# Altium schematic sheet Stepper_Driver_Arduino_uC.SchDoc
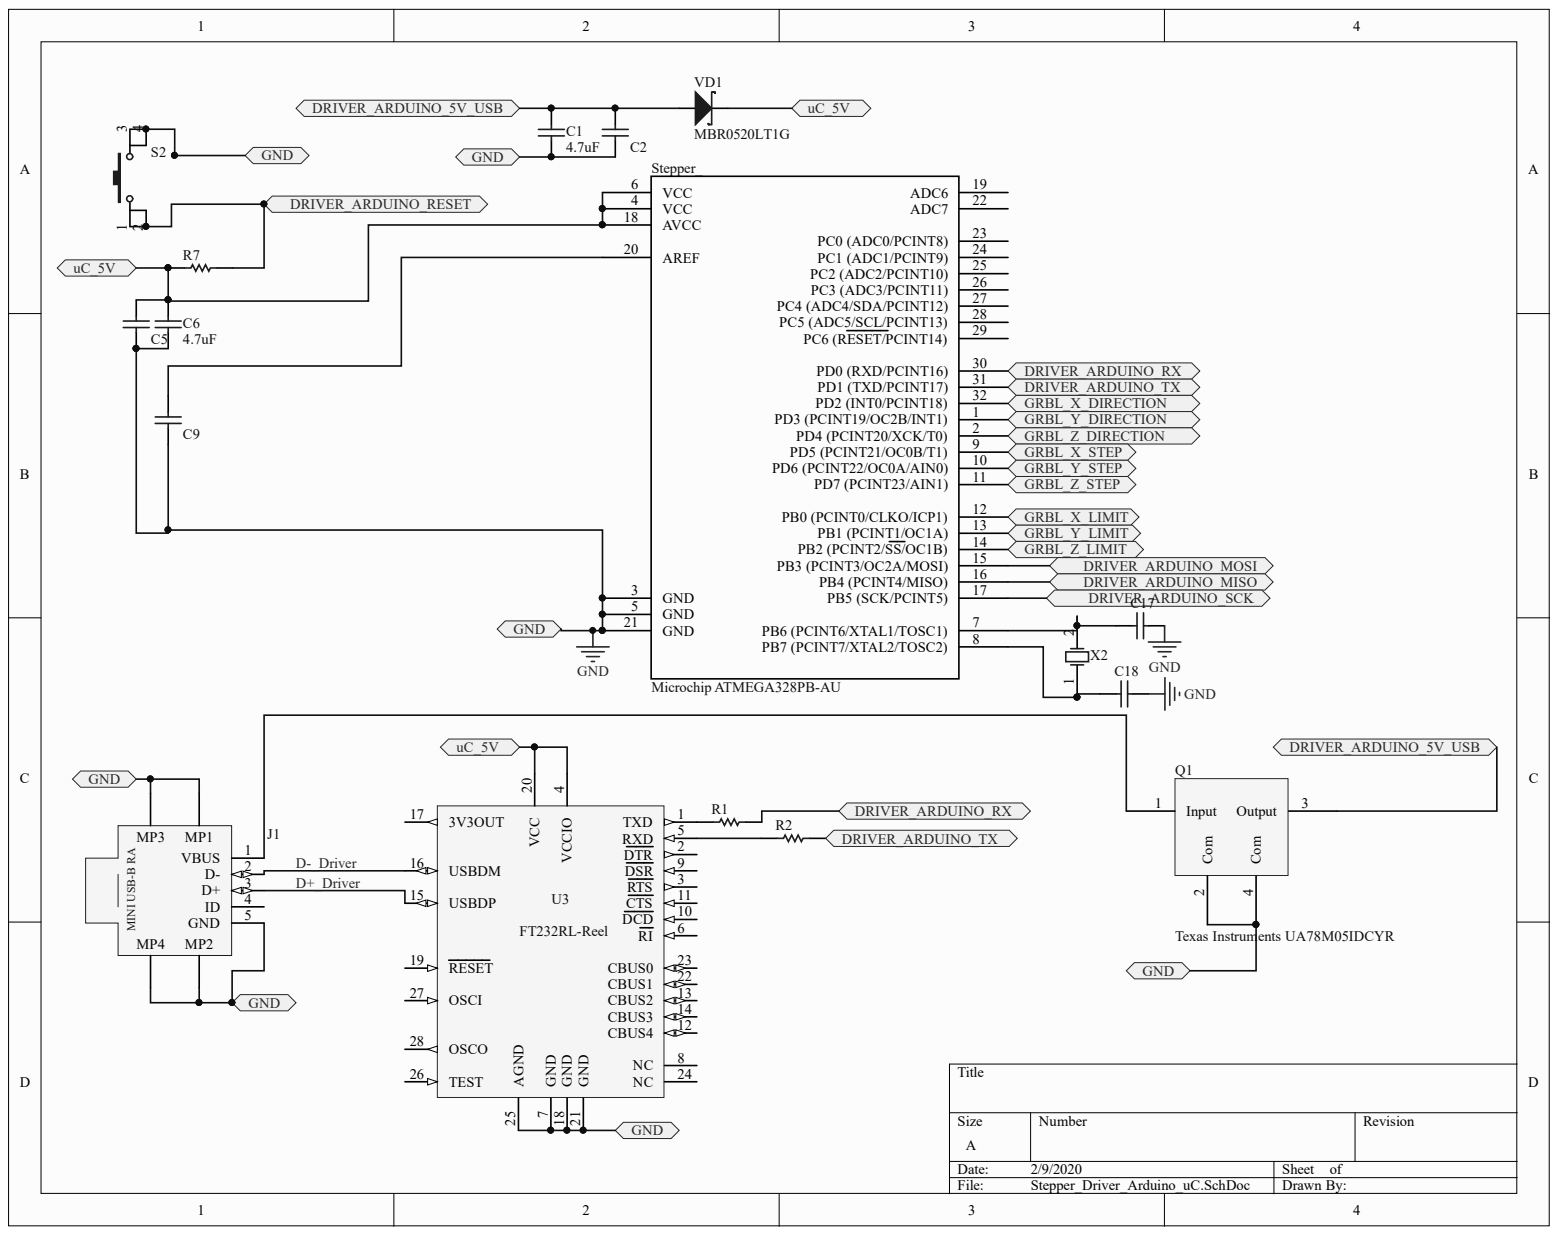
[diagram p0: Stepper_Driver_Arduino_uC.SchDoc]

## Parts
C1  4.7uF  [PCB-o44e25ijbg7jfnbnmamr-1]
C2  KEMET C0603C104Z3VACTU  [PCB-2vx2ptnht2odfp520b78-1]  (value not drawn)
C5  KEMET C0603C104Z3VACTU  [PCB-2vx2ptnht2odfp520b78-1]  (value not drawn)
C6  4.7uF  [PCB-o44e25ijbg7jfnbnmamr-1]
C9  KEMET C0603C104Z3VACTU  [PCB-2vx2ptnht2odfp520b78-1]  (value not drawn)
C17  Yageo CC0603JRNPO9BN200  [0603C_B]  (value not drawn)
C18  Yageo CC0603JRNPO9BN200  [0603C_B]  (value not drawn)
J1  USB Mini UJ2-MBH-1-SMT-TR  [USB-MINI-B-RA-5PIN-SMD]  (value not drawn)
Q1  Texas Instruments UA78M05IDCYR  Manufacturer Part Number: UA78M05IDCYR  [PCB-lwczzy0ex47e627hfo4t-1]
R1  RES-2  [SMD0603GRID25PAD90]  (value not drawn)
R2  RES-2  [SMD0603GRID25PAD90]  (value not drawn)
R7  RES-2  [SMD0603GRID25PAD90]  (value not drawn)
S2  TE Connectivity 2-1437565-9  [PCB-jknttd96a2zks7mphmau-1]  (value not drawn)
Stepper_  Microchip ATMEGA328PB-AU  [PCB-whr5813yqjt9pre9pi4d-1]
U3  FT232RL-Reel  [SSOP-28_L]
VD1  MBR0520LT1G  [SOD-123_B]
X2  SYM-2b32e236d469f4f5-1  [PCB-gy4gor98huej9pvydkys-1]  (value not drawn)

## Ports
DRIVER_ARDUINO_5V_USB, DRIVER_ARDUINO_MISO, DRIVER_ARDUINO_MOSI, DRIVER_ARDUINO_RESET, DRIVER_ARDUINO_RX, DRIVER_ARDUINO_SCK, DRIVER_ARDUINO_TX, GND, GRBL_X_DIRECTION, GRBL_X_LIMIT, GRBL_X_STEP, GRBL_Y_DIRECTION, GRBL_Y_LIMIT, GRBL_Y_STEP, GRBL_Z_DIRECTION, GRBL_Z_LIMIT, GRBL_Z_STEP, UC_5V

## Nets
UC_5V: C5.1 C6.1 R7.1 Stepper_.4(VCC) Stepper_.6(VCC) Stepper_.18(AVCC) U3.4(VCCIO) U3.20(VCC) VD1.1 ; port UC_5V
D+_DRIVER: J1.3(D+) U3.15(USBDP)
D-_DRIVER: J1.2(D-) U3.16(USBDM)
DRIVER_ARDUINO_5V_USB: C1.1 C2.1 Q1.3(Output) VD1.2 ; port DRIVER_ARDUINO_5V_USB
DRIVER_ARDUINO_MISO: Stepper_.16(PB4_(PCINT4/MISO)) ; port DRIVER_ARDUINO_MISO
DRIVER_ARDUINO_MOSI: Stepper_.15(PB3_(PCINT3/OC2A/MOSI)) ; port DRIVER_ARDUINO_MOSI
DRIVER_ARDUINO_RESET: R7.2 S2.1 S2.2 ; port DRIVER_ARDUINO_RESET
DRIVER_ARDUINO_RX: R1.2 Stepper_.30(PD0_(RXD/PCINT16)) ; port DRIVER_ARDUINO_RX
DRIVER_ARDUINO_SCK: Stepper_.17(PB5_(SCK/PCINT5)) ; port DRIVER_ARDUINO_SCK
DRIVER_ARDUINO_TX: R2.2 Stepper_.31(PD1_(TXD/PCINT17)) ; port DRIVER_ARDUINO_TX
GND: C1.2 C2.2 C5.2 C6.2 C9.2 C17.2 C18.2 J1.5(GND) J1.MP1 J1.MP2 J1.MP3 J1.MP4 Q1.2(Com) Q1.4(Com) S2.3 S2.4 Stepper_.3(GND) Stepper_.5(GND) Stepper_.21(GND) U3.7(GND) U3.18(GND) U3.21(GND) U3.25(AGND) ; port GND
GRBL_X_DIRECTION: Stepper_.32(PD2_(INT0/PCINT18)) ; port GRBL_X_DIRECTION
GRBL_X_LIMIT: Stepper_.12(PB0_(PCINT0/CLKO/ICP1)) ; port GRBL_X_LIMIT
GRBL_X_STEP: Stepper_.9(PD5_(PCINT21/OC0B/T1)) ; port GRBL_X_STEP
GRBL_Y_DIRECTION: Stepper_.1(PD3_(PCINT19/OC2B/INT1)) ; port GRBL_Y_DIRECTION
GRBL_Y_LIMIT: Stepper_.13(PB1_(PCINT1/OC1A)) ; port GRBL_Y_LIMIT
GRBL_Y_STEP: Stepper_.10(PD6_(PCINT22/OC0A/AIN0)) ; port GRBL_Y_STEP
GRBL_Z_DIRECTION: Stepper_.2(PD4_(PCINT20/XCK/T0)) ; port GRBL_Z_DIRECTION
GRBL_Z_LIMIT: Stepper_.14(PB2_(PCINT2/SS/OC1B)) ; port GRBL_Z_LIMIT
GRBL_Z_STEP: Stepper_.11(PD7_(PCINT23/AIN1)) ; port GRBL_Z_STEP
NetC9_1: C9.1 Stepper_.20(AREF)
NetC17_1: C17.1 Stepper_.7 X2.2
NetC18_1: C18.1 Stepper_.8(PB7_(PCINT7/XTAL2/TOSC2)) X2.1
NetJ1_1: J1.1(VBUS) Q1.1(Input)
NetR1_1: R1.1 U3.1(TXD)
NetR2_1: R2.1 U3.5(RXD)

Not connected: J1 4(ID); Stepper_ 19(ADC6) 22(ADC7) 23(PC0_(ADC0/PCINT8)) 24(PC1_(ADC1/PCINT9)) 25(PC2_(ADC2/PCINT10)) 26(PC3_(ADC3/PCINT11)) 27(PC4_(ADC4/SDA/PCINT12)) 28(PC5_(ADC5/SCL/PCINT13)) 29(PC6_(RESET/PCINT14)); U3 2(DTR) 3(RTS) 6(RI) 8(NC) 9(DSR) 10(DCD) 11(CTS) 12(CBUS4) 13(CBUS2) 14(CBUS3) 17(3V3OUT) 19(RESET) 22(CBUS1) 23(CBUS0) 24(NC) 26(TEST) 27(OSCI) 28(OSCO)

## Library data of the parts
C1 C6 = CMP-4caf8516eb679368-2: CL10 Series 4.7 uF 10 V ±10 % Tolerance X5R SMT Multilayer Ceramic Capacitor; Manufacturer Part Number: CL10A475KP8NNNC; Capacitance: 4.7e-06 F; Capacitance Tolerance: ±10%; Lead-Free Status: Lead Free; Voltage Rating (DC): 10.0 V; Case/Package: 0603; Component Kind: Standard; Dielectric Characteristic: X5R; Library Name: CommunityVault; Mounting Style: Surface Mount; OctopartID: 4caf8516eb679368; Octopart URL: http://octopart.com/cl10a475kp8nnnc-samsung-11764398; RoHS: Compliant
C2 C5 C9 = CMP-123607-11: Capacitor: ceramic; MLCC; 100nF; 25VDC; Y5V; -20÷+80%; SMD; 0603; Capacitance: 0.1uF; Component Kind: Standard; Library Name: CommunityVault; Manufacturer: KEMET; Manufacturer Part Number: C0603C104Z3VACTU
C17 C18 = CMP-598090fbb8168647-3: 0603 20 pF 50 V ±5 % Tolerance NP0 SMT Multilayer Ceramic Capacitor; Capacitance: 20.0 pF; Capacitance Tolerance: ±5%; Dielectric Characteristic: C0G/NP0; Lead-Free Status: Lead Free; Voltage Rating (DC): 50.0 V; Case/Package: 0603, 1608; Component Kind: Standard; Library Name: CommunityVault; Manufacturer Part Number: CC0603JRNPO9BN200; Mounting Style: Surface Mount; Number of Pins: 2; OctopartID: 598090fbb8168647; Octopart Url: http://octopart.com/cc0603jrnpo9bn200-yageo-7455984; Packaging: Cut Tape (CT); RoHS: Compliant; Seller Landing  Url: https://octopart-clicks.com/click/track?country=US&ak=8157f166&sig=0860d5f&sid=1106&ppid=7455984&vpid=198823968&ct=offers
J1 = CMP-aa1e67dd370375d9-4: USB Connectors USB 2.0 mini B jack 5 pin Horizontal SMT; Manufacturer: CUI; Lead-Free Status: Lead Free; Category: USB Connectors; Component Kind: Standard; Digikey: 102-4003-1-ND; Library Name: CommunityVault; Manufacturer Part Number: UJ2-MBH-1-SMT-TR; OctopartID: aa1e67dd370375d9; Octopart URL: http://octopart.com/uj2-mbh-1-smt-tr-cui-80328827; RoHS: Compliant; Supplier 1: Mouser; Supplier Part Number 1: 490-UJ2-MBH-1-SMT
Q1 = CMP-5a8293807ae38545-1: 500-mA, 25-V linear voltage regulator 4-SOT-223 -40 to 125; Dropout Voltage: 2.00 V; Input Voltage (DC): 25.0 V (max); Number of Regulated Outputs: 1; Output Voltage: 4.75 V (min), 5.00 V (max); Quiescent Current: 4.50 mA; Case/Package: SOT-223; Component Kind: Standard; Library Name: CommunityVault; Lifecycle Status: Active; Mounting Style: Surface Mount; Octopart URL: https://octopart.com/ua78m05idcyr-texas+instruments-525575; Operating Temperature: 125 °C (max); Packaging: Cut Tape (CT), Tape & Reel (TR); REACH SVHC Compliance: No SVHC
R1 R2 R7 = CMP-68a0a2e72c4eb767-17: RC Series 0603 0.1 W 1 kOhms 5% ±100 ppm/°C SMT Thick Film Chip Resistor; Manufacturer Part Number: RC0603JR-071KL; Lead-Free Status: Lead Free; Power Rating: 100 mW; Resistance: 1.00 kΩ; Voltage Rating (DC): 50.0 V; Component Kind: Standard; Library Name: CommunityVault; Mounting Style: Surface Mount; OctopartID: 68a0a2e72c4eb767; Octopart Url: http://octopart.com/rc0603jr-071kl-yageo-55402888; Packaging: Cut Tape (CT), Tape & Reel (TR); REACH SVHC Compliance: No SVHC; Resistance Tolerance: ±5%; RoHS: Compliant; Seller Landing  Url: https://octopart-clicks.com/click/track?country=US&ak=8157f166&sig=04f502c&sid=1106&ppid=55402888&vpid=198667460&ct=offers; Size-Height: 450 µm; Size-Length: 1.60 mm; Size-Width: 800 µm
S2 = CMP-34bcffd9c077eb7b-4: Switch Tactile OFF (ON) SPST Round Button Gull Wing 0.05A 24VDC 1VA 9.18N SMD T/R; Component Kind: Standard; Library Name: CommunityVault
Stepper_ = CMP-216eecc682ecfea9-4: AVR 32KBYTES Flash, 1KBYTES EEPROM, 2KBYTES Ram, W - 20MHZ, TQFP, Ind Temp, Green 5 V; Component Kind: Standard; Library Name: CommunityVault; OctopartID: 216eecc682ecfea9
U3 = CMP-0078-00029-4: USB UART Asynchronous Serial Data Transfer Chip, 28-pin SSOP, Tape and Reel; Lead-Free Status: Lead Free; Component Kind: Standard; Library Name: CommunityVault; Manufacturer Part Number: FT232RL-REEL; OctopartID: 4f3d8713e14e90fa; Octopart Url: https://octopart.com/ft232rl-reel-ftdi-19172117; RoHS: Compliant
VD1 = CMP-3786467-10: Rectifier, Schottky Power; Plastic Sod-123; 0.5 a @ +90c If; +125; 20v; 1000; Current Rating: 0.5 A; Forward Voltage: 0.385 V; Lead-Free Status: Lead Free; Polarity: Standard; Voltage Rating (DC): 20.0 V; Case/Package: SOD-123; Component Kind: Standard; Library Name: CommunityVault; Lifecycle Status: Active; Manufacturer Part Number: MBR0520LT1G; Mounting Style: Surface Mount; Number of Pins: 2; OctopartID: 81934899af805e54; Octopart Url: http://octopart.com/mbr0520lt1g-on+semiconductor-2933; REACH SVHC Compliance: No SVHC; RoHS: Compliant; Seller Landing  Url: https://octopart-clicks.com/click/track?country=US&ak=8157f166&sig=02b8313&sid=5489&ppid=2933&vpid=120602749&ct=offers
X2 = CMP-2b32e236d469f4f5-1: Crystal 16MHz; +/-50ppm; 2-Pin HC-49-S; Manufacturer Part Number: AS-16.000-18; Equivalent Series Resistance (ESR): 40.0 Ω; Frequency: 16.0 MHz; Load Capacitance: 18.0 pF; Component Kind: Standard; Library Name: CommunityVault; Mounting Style: Through Hole; Number of Pins: 2; REACH SVHC Compliance: No SVHC; RoHS: Compliant
